# Altium schematic sheet On_Off.SchDoc("On Off Controller")
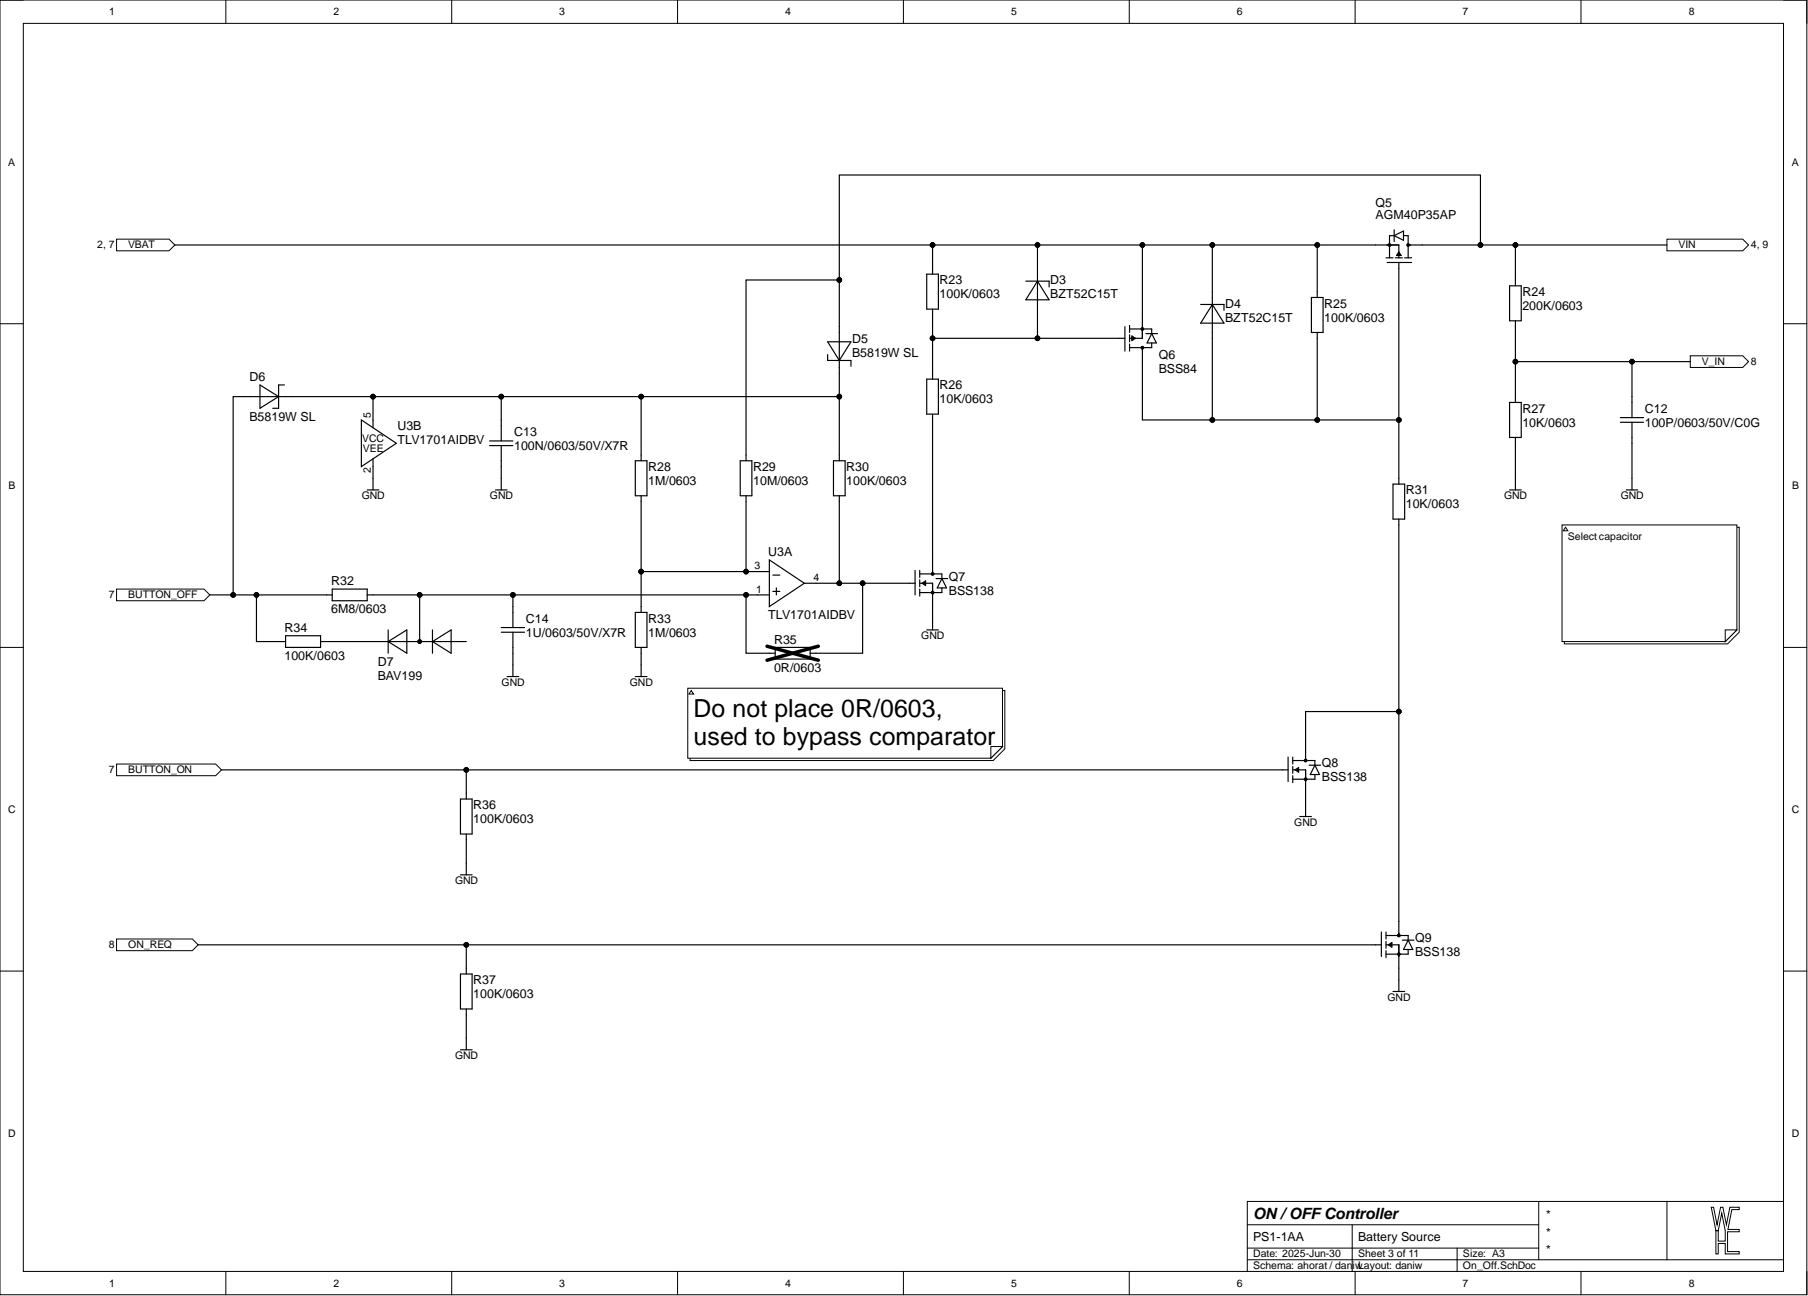
[diagram p0: On_Off.SchDoc]

## Parts
C12  100P/0603/50V/C0G  [CAP0603_C0G]
C13  100N/0603/50V/X7R  [CAP0603_X7R]
C14  1U/0603/50V/X7R  [CAP0603_X7R]
D3  BZT52C15T  [SOD1608X065]
D4  BZT52C15T  [SOD1608X065]
D5  B5819W SL  [SOD3718X125]
D6  B5819W SL  [SOD3718X125]
D7  BAV199  [SOT2395P280X125-3-SE]
Q5  AGM40P35AP  [SON065P330X330X075-5-TH245X175]
Q6  BSS84  [SOT2395P280X125-3-SE]
Q7  BSS138  [SOT2395P280X125-3-SE]
Q8  BSS138  [SOT2395P280X125-3-SE]
Q9  BSS138  [SOT2395P280X125-3-SE]
R23  100K/0603  [R0603-0.40-WW]
R24  200K/0603  [R0603-0.40-WW]
R25  100K/0603  [R0603-0.40-WW]
R26  10K/0603  [R0603-0.40-WW]
R27  10K/0603  [R0603-0.40-WW]
R28  1M/0603  [R0603-0.40-WW]
R29  10M/0603  [R0603-0.40-WW]
R30  100K/0603  [R0603-0.40-WW]
R31  10K/0603  [R0603-0.40-WW]
R32  6M8/0603  [R0603-0.40-WW]
R33  1M/0603  [R0603-0.40-WW]
R34  100K/0603  [R0603-0.40-WW]
R35  0R/0603  [R0603-0.40-WW]
R36  100K/0603  [R0603-0.40-WW]
R37  100K/0603  [R0603-0.40-WW]
U3  TLV1701AIDBV  [SOT2395P280X125-5-NW]  (parts A,B)

## Ports
BUTTON_OFF, BUTTON_ON, ON_REQ, V_IN, VBAT, VIN

## Nets
GND: C12.2 C13.2 C14.2 Q7.2 Q8.2 Q9.2 R27.2 R33.2 R36.2 R37.2 U3.2
BUTTON_OFF: D6.2 R32.1 R34.1 ; port BUTTON_OFF
BUTTON_ON: Q8.1 R36.1 ; port BUTTON_ON
ON_REQ: Q9.1 R37.1 ; port ON_REQ
V_IN: C12.1 R24.2 R27.1 ; port V_IN
VBAT: D3.1 D4.1 Q5.1,2,3 Q6.2 R23.1 R25.1 ; port VBAT
VIN: D5.2 Q5.5 R24.1 R29.1 ; port VIN
NetC13_1: C13.1 D5.1 D6.1 R28.1 R30.1 U3.5
NetC14_1: C14.1 D7.3 R32.2 R35.1 U3.1
NetD3_2: D3.2 Q6.1 R23.2 R26.1
NetD4_2: D4.2 Q5.4 Q6.3 R25.2 R31.1
NetD7_1: D7.1
NetD7_2: D7.2 R34.2
NetQ7_1: Q7.1 R30.2 R35.2 U3.4
NetQ7_3: Q7.3 R26.2
NetQ8_3: Q8.3 Q9.3 R31.2
NetR28_2: R28.2 R29.2 R33.1 U3.3

## Library data of the parts
C12 = 100P/0603/50V/C0G: CAP SM 0603 100pF 50V C0G; Manufacturer: GENERIC; Manufacturer 1: YAGEO; Manufacturer Part Number 1: CC0603JRNPO9BN101; Absolute Value: 1E-10; Database Table Name: C$; Eurocircuits GPN: GPC0603101-C0G; Component Kind: Standard; HelpURL: https://www.yageo.com/upload/media/product/productsearch/datasheet/mlcc/UPY-GP_NP0_16V-to-50V_18.pdf; JLC Type: Basic; Manufacturer 2: YAGEO; Manufacturer Part Number 2: CC0603KRNPO9BN101; Manufacturer Part Number 3: CL10C101JB8NNNC; Package: 0603; Part Number: 100P/0603/50V/C0G; Supplier 1: Digi-Key; Supplier 2: Digi-Key; Supplier 3: LCSC; Supplier Part Number 1: 311-1069-1-ND; Supplier Part Number 2: 311-3600-1-ND; Supplier Part Number 3: C14858; Value: 100pF; Library Name: daniw_altium_lib.DbLib
C13 = 100N/0603/50V/X7R: CAP SM 0603 100nF 50V X7R; Manufacturer: GENERIC; Manufacturer 1: YAGEO; Manufacturer Part Number 1: CC0603KPX7R9BB104; Absolute Value: 1E-7; Database Table Name: C$; Eurocircuits GPN: GPC0603104; Component Kind: Standard; HelpURL: https://www.yageo.com/upload/media/product/app/datasheet/mlcc/upy-gphc_x7r_6_3v-to-250v.pdf; JLC Type: Basic; Manufacturer 2: YAGEO; Manufacturer Part Number 2: CC0603MRX7R9BB104; Manufacturer Part Number 3: CC0603KRX7R9BB104; Package: 0603; Part Number: 100N/0603/50V/X7R; Supplier 1: Digi-Key; Supplier 2: Digi-Key; Supplier 3: LCSC; Supplier Part Number 1: 311-3567-1-ND; Supplier Part Number 2: 311-4110-1-ND; Supplier Part Number 3: C14663; Value: 100nF; Library Name: daniw_altium_lib.DbLib
C14 = 1U/0603/50V/X7R: CAP SM 0603 1.0uF 50V X7R; Manufacturer: GENERIC; Manufacturer 1: YAGEO; Manufacturer Part Number 1: CC0603KRX7R9BB105; Absolute Value: 1E-6; Database Table Name: C$; Eurocircuits GPN: GPC0603105; Component Kind: Standard; HelpURL: https://www.yageo.com/upload/media/product/app/datasheet/mlcc/upy-gphc_x7r_6_3v-to-250v.pdf; Package: 0603; Part Number: 1U/0603/50V/X7R; Supplier 1: Digi-Key; Supplier Part Number 1: 311-2082-1-ND; Value: 1.0uF; Library Name: daniw_altium_lib.DbLib
D3 D4 = BZT52C15T: DIODE ZENER 15V 100MW SOD523; Manufacturer: Micro Commercial Co; Manufacturer Part Number 1: BZT52C15T-TP; Database Table Name: D$; Absolute Value: 15 V; Component Kind: Standard; HelpURL: https://www.mccsemi.com/pdf/Products/BZT52C(B)2V4T-BZT52C(B)39T(SOD-523).pdf; Manufacturer 1: Micro Commercial Co; Manufacturer 2: Diodes Incorporated; Manufacturer 3: Diodes Incorporated; Manufacturer Part Number 2: BZT52C15T-7; Manufacturer Part Number 3: BZT52C15T-7; Package: SC-79, SOD-523; Part Number: BZT52C15T; Supplier 1: Digikey; Supplier 2: Digikey; Supplier 3: LCSC; Supplier Part Number 1: BZT52C15T-TPMSCT-ND; Supplier Part Number 2: BZT52C15T-7DICT-ND; Supplier Part Number 3: C460787; Value: BZT52C15T; Library Name: daniw_altium_lib.DbLib
D5 D6 = B5819W SL: 40V 600mV@1A 1A SOD-123 Schottky Barrier Diodes; Manufacturer: GENERIC; Manufacturer 1: SMC Diode Solutions; Database Table Name: D$; Absolute Value: 40V; Component Kind: Standard; HelpURL: https://datasheet.lcsc.com/lcsc/2304140030_Jiangsu-Changjing-Electronics-Technology-Co---Ltd--B5819W-SL_C8598.pdf; JLC Type: Basic; Manufacturer 3: Jiangsu Changjing Electronics Technology Co., Ltd.; Manufacturer Part Number 1: B5819WSL; Manufacturer Part Number 3: B5819W SL; Package: SOD-123; Part Number: B5819W SL; Supplier 1: Digikey; Supplier 3: LCSC; Supplier Part Number 1: 1655-B5819WSLCT-ND; Supplier Part Number 3: C8598; Value: B5819W SL; Library Name: daniw_altium_lib.DbLib
D7 = BAV199: Silicon Low Leakage Diode 85V; Manufacturer: Infineon Technologies; Manufacturer Part Number 1: BAV199E6433HTMA1; Database Table Name: D$; Absolute Value: 85V; Component Kind: Standard; HelpURL: https://www.infineon.com/dgdl/bav199series.pdf?folderId=db3a30431400ef6801141c748874044e&fileId=db3a30431400ef6801141cba733104e5; Manufacturer 1: Infineon Technologies; Manufacturer 3: LRC; Manufacturer Part Number 3: LBAV199LT1G; Package: SOT23; Part Number: BAV199; Supplier 1: Digikey; Supplier 3: LCSC; Supplier Part Number 1: BAV199E6433HTMA1CT-ND; Supplier Part Number 3: C12740; Value: BAV199; Library Name: daniw_altium_lib.DbLib
Q5 = AGM40P35AP: 40V 35A 11mΩ@10V,15A 30W 1.7V 1 piece P-channel PDFN(3.3x3.3) MOSFETs ROHS; Manufacturer: AGM-Semi; Database Table Name: T$; Component Kind: Standard; HelpURL: https://www.lcsc.com/datasheet/lcsc_datasheet_2504101957_AGM-Semi-AGM40P35AP_C18221399.pdf; Manufacturer 3: AGM-Semi; Manufacturer Part Number 3: AGM40P35AP; Package: 8-PowerVDFN; Part Number: AGM40P35AP; Supplier 3: LCSC; Supplier Part Number 3: C18221399; Library Name: daniw_altium_lib.DbLib
Q6 = BSS84: 50V P-Channel MOSFET; Manufacturer: GENERIC; Manufacturer 1: Good-Ark Semiconductor; Database Table Name: T$; Component Kind: Standard; HelpURL: https://goodarksemi.com/docs/datasheets/mosfets/BSS84.pdf; JLC Type: Extended; Manufacturer 2: LRC; Manufacturer 3: Jiangsu Changjing Electronics Technology Co., Ltd.; Manufacturer Part Number 1: BSS84; Manufacturer Part Number 2: LBSS84LT1G; Manufacturer Part Number 3: BSS84; Package: SOT23; Part Number: BSS84; Supplier 1: Digi-Key; Supplier 2: LCSC; Supplier 3: LCSC; Supplier Part Number 1: 4786-BSS84CT-ND; Supplier Part Number 2: C8492; Supplier Part Number 3: C114481; Library Name: daniw_altium_lib.DbLib
Q7-Q9 = BSS138: N-Kanal 50 V 220mA (Ta) 350mW (Ta) Oberflächenmontage SOT-23; Manufacturer: GENERIC; Manufacturer 1: ANBON SEMICONDUCTOR (INT'L) LIMITED; Database Table Name: T$; Component Kind: Standard; HelpURL: https://mm.digikey.com/Volume0/opasdata/d220001/medias/docus/3037/BSS138.pdf; JLC Type: Extended; Manufacturer 2: FOSAN; Manufacturer 3: FOSAN; Manufacturer Part Number 1: BSS138; Manufacturer Part Number 2: BSS138; Manufacturer Part Number 3: BSS138; Package: SOT23; Part Number: BSS138; Supplier 1: Digi-Key; Supplier 2: LCSC; Supplier 3: LCSC; Supplier Part Number 1: 4530-BSS138CT-ND; Supplier Part Number 2: C2926186; Supplier Part Number 3: C2926186; Library Name: daniw_altium_lib.DbLib
R23 R25 R30 R34 R36 R37 = 100K/0603: RES SM 0603 100K; Manufacturer: GENERIC; Manufacturer 1: YAGEO; Manufacturer Part Number 1: RC0603FR-13100KL; Absolute Value: 100000; Database Table Name: R$; Eurocircuits GPN: GPR0603100K; Component Kind: Standard; HelpURL: https://www.yageo.com/upload/media/product/productsearch/datasheet/rchip/PYu-RC_Group_51_RoHS_L_12.pdf; JLC Type: Basic; Manufacturer 2: YAGEO; Manufacturer 3: UNI-ROYAL(Uniroyal Elec); Manufacturer Part Number 2: RC0603FR-10100KL; Manufacturer Part Number 3: 0603WAF1003T5E; Package: 0603; Part Number: 100K/0603; Supplier 1: Digi-Key; Supplier 2: Digi-Key; Supplier 3: LCSC; Supplier Part Number 1: 13-RC0603FR-13100KLCT-ND; Supplier Part Number 2: 13-RC0603FR-10100KLCT-ND; Supplier Part Number 3: C25803; Value: 100K; Library Name: daniw_altium_lib.DbLib
R24 = 200K/0603: RES SM 0603 200K; Manufacturer: GENERIC; Manufacturer 1: YAGEO; Manufacturer Part Number 1: RC0603FR-13200KL; Absolute Value: 200000; Database Table Name: R$; Eurocircuits GPN: GPR0603200K; Component Kind: Standard; HelpURL: https://www.yageo.com/upload/media/product/app/datasheet/rchip/pyu-rc_group_51_rohs_l.pdf; JLC Type: Basic; Manufacturer 2: YAGEO; Manufacturer 3: UNI-ROYAL(Uniroyal Elec); Manufacturer Part Number 2: RC0603FR-10200KL; Manufacturer Part Number 3: 0603WAF2003T5E; Package: 0603; Part Number: 200K/0603; Supplier 1: Digi-Key; Supplier 2: Digi-Key; Supplier 3: LCSC; Supplier Part Number 1: 13-RC0603FR-13200KLCT-ND; Supplier Part Number 2: 13-RC0603FR-10200KLCT-ND; Supplier Part Number 3: C25811; Value: 200K; Library Name: daniw_altium_lib.DbLib
R26 R27 R31 = 10K/0603: RES SM 0603 10K; Manufacturer: GENERIC; Manufacturer 1: YAGEO; Manufacturer Part Number 1: RC0603FR-1310KL; Absolute Value: 10000; Database Table Name: R$; Eurocircuits GPN: GPR060310K; Component Kind: Standard; HelpURL: https://www.yageo.com/upload/media/product/productsearch/datasheet/rchip/PYu-RC_Group_51_RoHS_L_12.pdf; JLC Type: Basic; Manufacturer 2: YAGEO; Manufacturer 3: UNI-ROYAL(Uniroyal Elec); Manufacturer Part Number 2: RC0603FR-1010KL; Manufacturer Part Number 3: 0603WAF1002T5E; Package: 0603; Part Number: 10K/0603; Supplier 1: Digi-Key; Supplier 2: Digi-Key; Supplier 3: LCSC; Supplier Part Number 1: 13-RC0603FR-1310KLCT-ND; Supplier Part Number 2: 13-RC0603FR-1010KLCT-ND; Supplier Part Number 3: C25804; Value: 10K; Library Name: daniw_altium_lib.DbLib
R28 R33 = 1M/0603: RES SM 0603 1M; Manufacturer: GENERIC; Manufacturer 1: YAGEO; Manufacturer Part Number 1: RC0603FR-131ML; Absolute Value: 1000000; Database Table Name: R$; Eurocircuits GPN: GPR06031M; Component Kind: Standard; HelpURL: https://www.yageo.com/upload/media/product/app/datasheet/rchip/pyu-rc_group_51_rohs_l.pdf; JLC Type: Basic; Manufacturer 2: YAGEO; Manufacturer 3: UNI-ROYAL(Uniroyal Elec); Manufacturer Part Number 2: RC0603FR-101ML; Manufacturer Part Number 3: 0603WAF1004T5E; Package: 0603; Part Number: 1M/0603; Supplier 1: Digi-Key; Supplier 2: Digi-Key; Supplier 3: LCSC; Supplier Part Number 1: 13-RC0603FR-131MLCT-ND; Supplier Part Number 2: 13-RC0603FR-101MLCT-ND; Supplier Part Number 3: C22935; Value: 1M; Library Name: daniw_altium_lib.DbLib
R29 = 10M/0603: RES SM 0603 10M; Manufacturer: GENERIC; Manufacturer 1: YAGEO; Manufacturer Part Number 1: RC0603FR-1310ML; Absolute Value: 10000000; Database Table Name: R$; Eurocircuits GPN: GPR060310M; Component Kind: Standard; HelpURL: https://www.yageo.com/upload/media/product/productsearch/datasheet/rchip/PYu-RC_Group_51_RoHS_L_12.pdf; JLC Type: Basic; Manufacturer 2: YAGEO; Manufacturer 3: UNI-ROYAL(Uniroyal Elec); Manufacturer Part Number 2: RC0603FR-1010ML; Manufacturer Part Number 3: 0603WAF1005T5E; Package: 0603; Part Number: 10M/0603; Supplier 1: Digi-Key; Supplier 2: Digi-Key; Supplier 3: LCSC; Supplier Part Number 1: 13-RC0603FR-1310MLCT-ND; Supplier Part Number 2: 13-RC0603FR-1010MLCT-ND; Supplier Part Number 3: C7250; Value: 10M; Library Name: daniw_altium_lib.DbLib
R32 = 6M8/0603: RES SM 0603 6M8; Manufacturer: GENERIC; Manufacturer 1: YAGEO; Manufacturer Part Number 1: RC0603FR-076M8L; Absolute Value: 6800000; Database Table Name: R$; Eurocircuits GPN: GPR06036M8; Component Kind: Standard; HelpURL: https://www.yageo.com/upload/media/product/app/datasheet/rchip/pyu-rc_group_51_rohs_l.pdf; JLC Type: Extended; Manufacturer 3: FOJAN; Manufacturer Part Number 3: FRC0603F6804TS; Package: 0603; Part Number: 6M8/0603; Supplier 1: Digi-Key; Supplier 3: LCSC; Supplier Part Number 1: 13-RC0603FR-076M8LCT-ND; Supplier Part Number 3: C3013205; Value: 6M8; Library Name: daniw_altium_lib.DbLib
R35 = 0R/0603: RES SM 0603 0R; Manufacturer: GENERIC; Manufacturer 1: YAGEO; Manufacturer Part Number 1: RC0603FR-070RL; Absolute Value: 0; Database Table Name: R$; Eurocircuits GPN: GPR06030R; Component Kind: Standard; HelpURL: https://www.yageo.com/upload/media/product/app/datasheet/rchip/pyu-rc_group_51_rohs_l.pdf; JLC Type: Basic; Manufacturer 2: YAGEO; Manufacturer 3: UNI-ROYAL(Uniroyal Elec); Manufacturer Part Number 2: RC0603FR-130RL; Manufacturer Part Number 3: 0603WAF0000T5E; Package: 0603; Part Number: 0R/0603; Supplier 1: Digi-Key; Supplier 2: Digi-Key; Supplier 3: LCSC; Supplier Part Number 1: 311-0.0HRCT-ND; Supplier Part Number 2: 13-RC0603FR-130RLCT-ND; Supplier Part Number 3: C21189; Value: 0R; Library Name: daniw_altium_lib.DbLib
U3 = TLV1701 SOT23: TLV1701 2.2-V to 36-V, microPower Comparato; Manufacturer: Texas Instruments; Manufacturer Part Number 1: TLV1701AIDBVR; Database Table Name: Integrated$; Component Kind: Standard; HelpURL: https://www.ti.com/lit/ds/symlink/tlv1701.pdf; Manufacturer 1: Texas Instruments; Manufacturer 2: Texas Instruments; Manufacturer 3: Texas Instruments; Manufacturer Part Number 2: TLV1701QDBVRQ1; Manufacturer Part Number 3: TLV1701AIDBVR; Package: SOT23-5; Part Number: TLV1701 SOT23; Supplier 1: Digi-Key; Supplier 2: Digi-Key; Supplier 3: LCSC; Supplier Part Number 1: 296-40599-1-ND; Supplier Part Number 2: 296-47458-1-ND; Supplier Part Number 3: C130035; Library Name: daniw_altium_lib.DbLib
